# Altium schematic sheet SW1.SchDoc
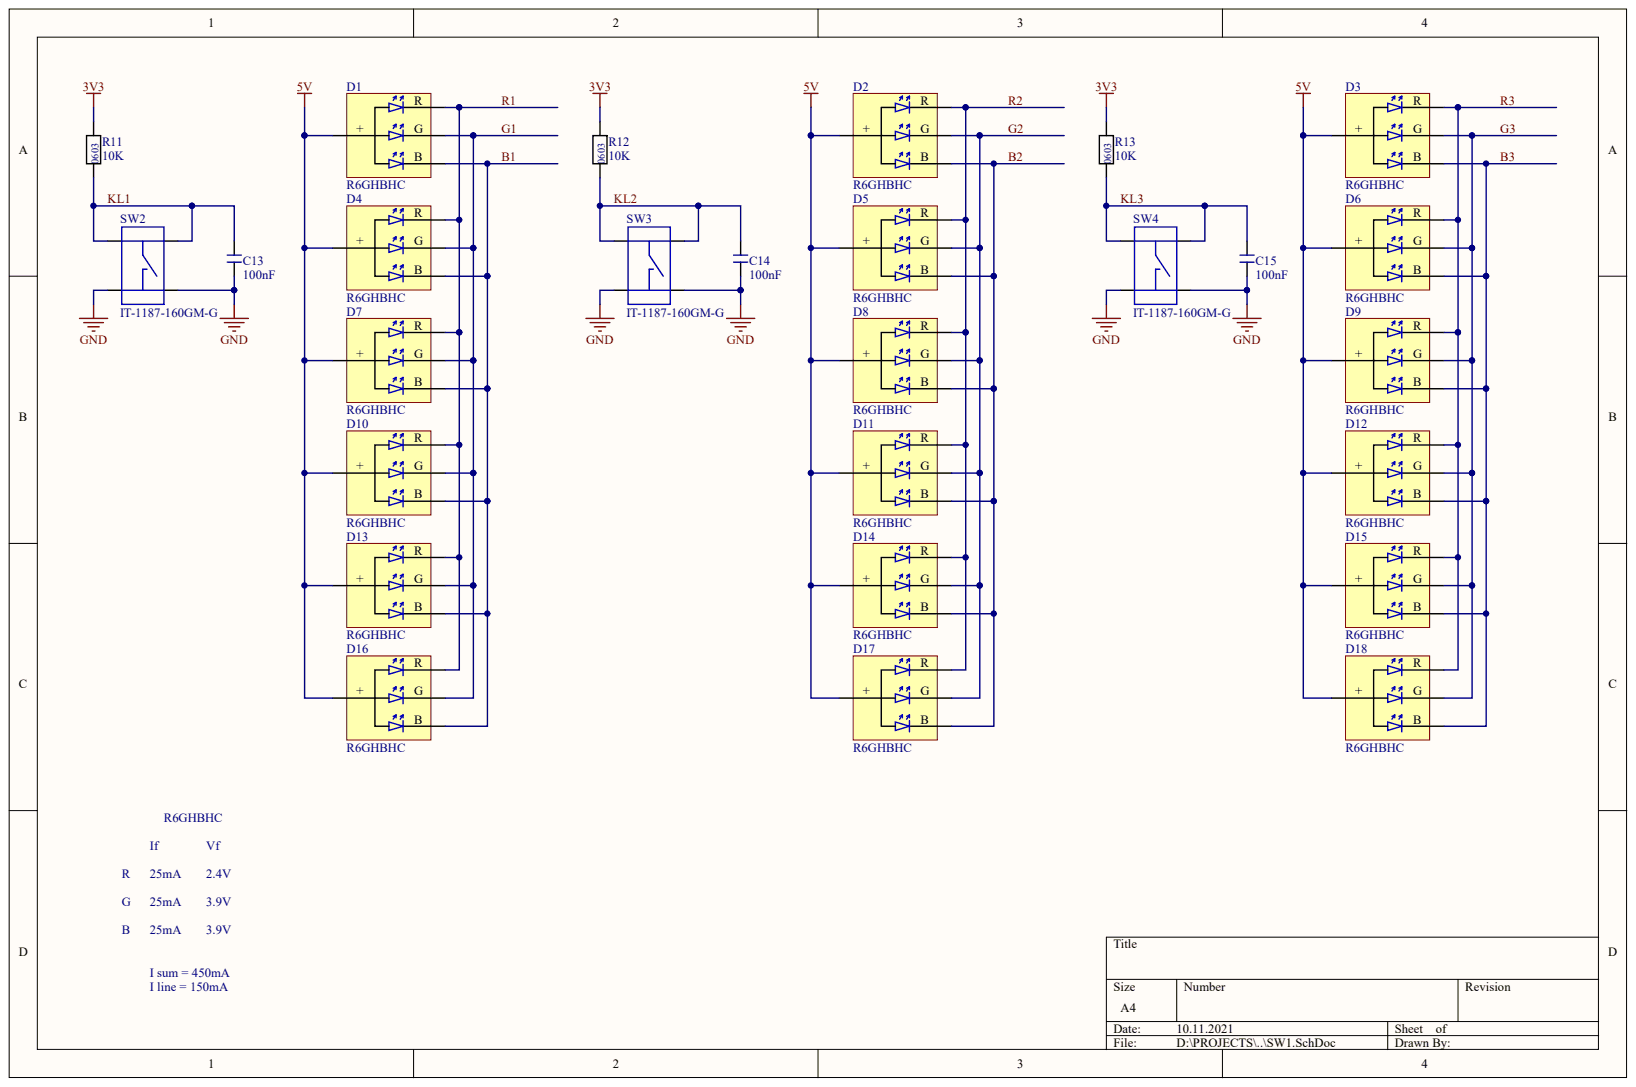
[diagram p0: SW1.SchDoc]

## Parts
C13  100nF
C14  100nF
C15  100nF
D1  R6GHBHC
D2  R6GHBHC
D3  R6GHBHC
D4  R6GHBHC
D5  R6GHBHC
D6  R6GHBHC
D7  R6GHBHC
D8  R6GHBHC
D9  R6GHBHC
D10  R6GHBHC
D11  R6GHBHC
D12  R6GHBHC
D13  R6GHBHC
D14  R6GHBHC
D15  R6GHBHC
D16  R6GHBHC
D17  R6GHBHC
D18  R6GHBHC
R11  10K
R12  10K
R13  10K
SW2  IT-1187-160GM-G
SW3  IT-1187-160GM-G
SW4  IT-1187-160GM-G

## Nets
3V3: R11.2 R12.2 R13.2
5V: D1.+ D2.+ D3.+ D4.+ D5.+ D6.+ D7.+ D8.+ D9.+ D10.+ D11.+ D12.+ D13.+ D14.+ D15.+ D16.+ D17.+ D18.+
GND: C13.1 C14.1 C15.1 SW2.3 SW2.4 SW3.3 SW3.4 SW4.3 SW4.4
B1: D1.B D4.B D7.B D10.B D13.B D16.B
B2: D2.B D5.B D8.B D11.B D14.B D17.B
B3: D3.B D6.B D9.B D12.B D15.B D18.B
G1: D1.G D4.G D7.G D10.G D13.G D16.G
G2: D2.G D5.G D8.G D11.G D14.G D17.G
G3: D3.G D6.G D9.G D12.G D15.G D18.G
KL1: C13.2 R11.1 SW2.1 SW2.2
KL2: C14.2 R12.1 SW3.1 SW3.2
KL3: C15.2 R13.1 SW4.1 SW4.2
R1: D1.R D4.R D7.R D10.R D13.R D16.R
R2: D2.R D5.R D8.R D11.R D14.R D17.R
R3: D3.R D6.R D9.R D12.R D15.R D18.R
